# Altium schematic sheet 01_Power.SchDoc
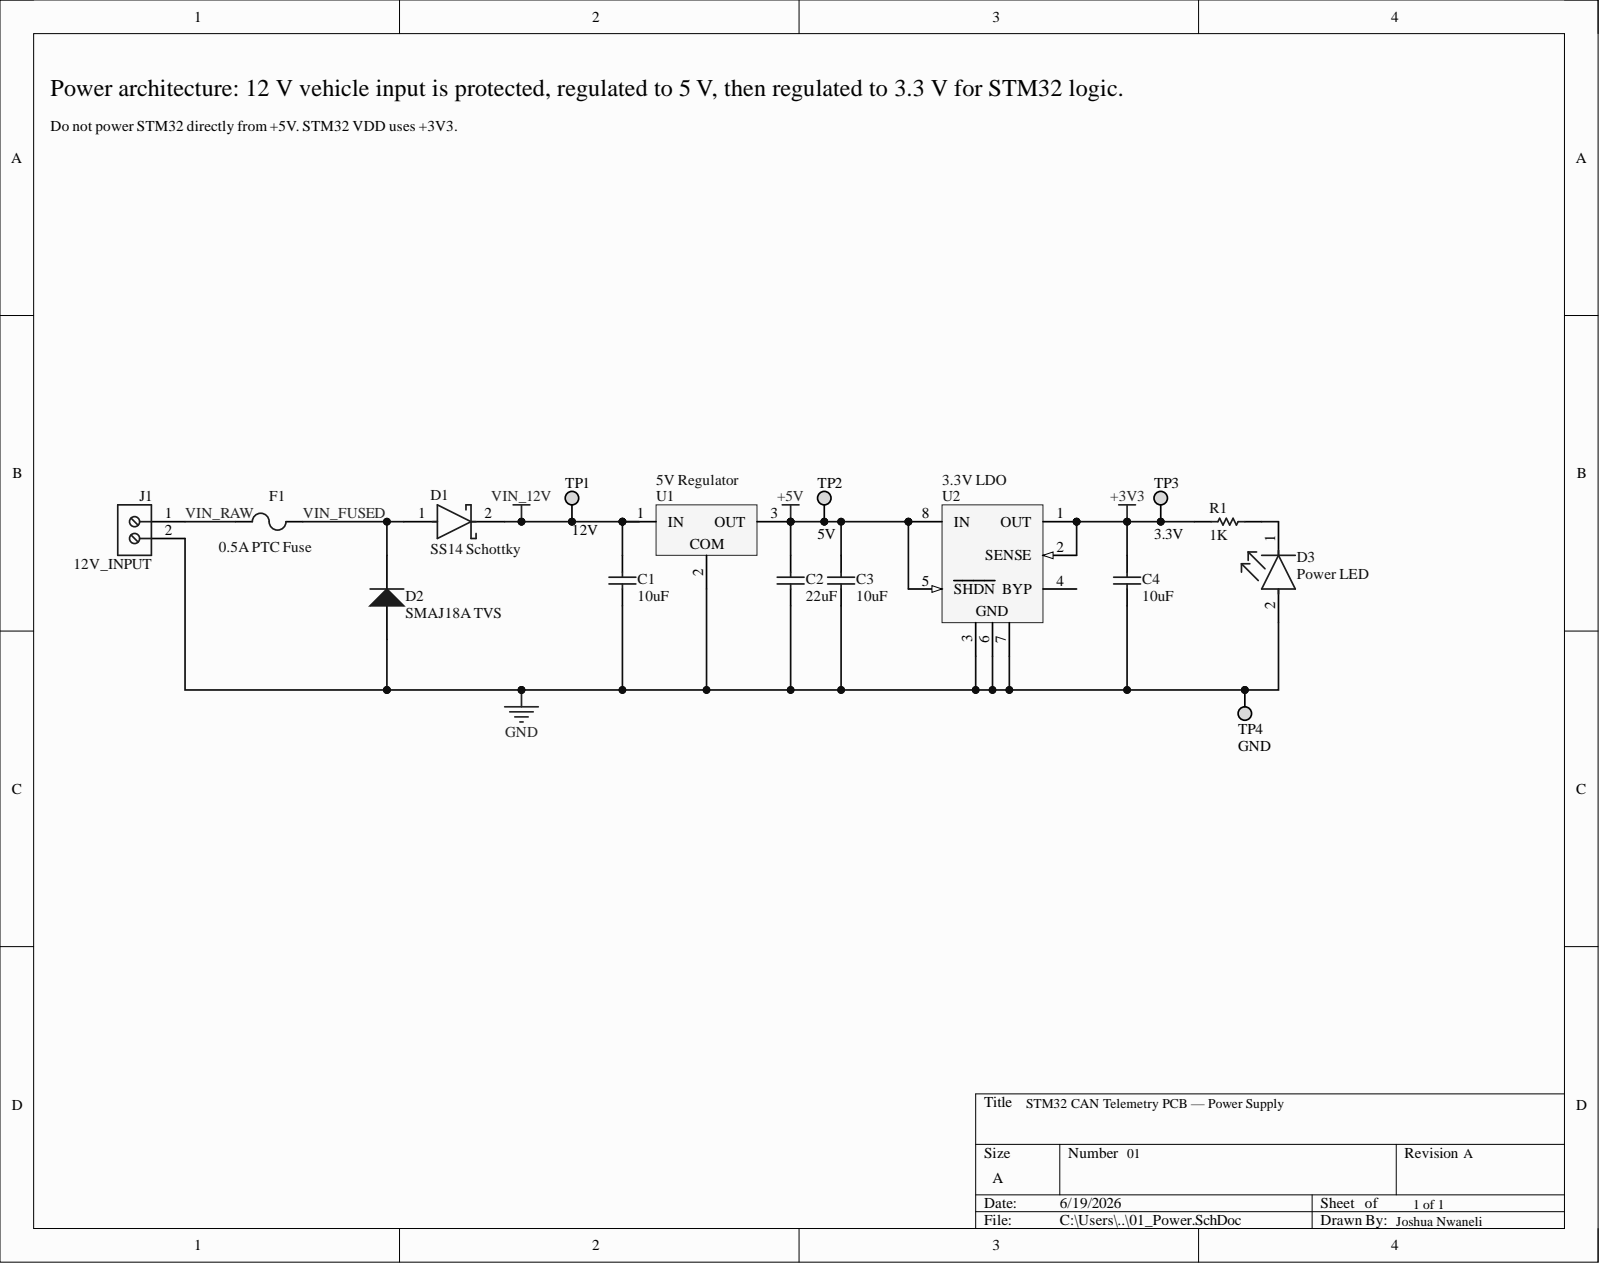
[diagram p0: 01_Power.SchDoc]

## Parts
C1  10uF  [CAPC2013X140X50ML20T25]
C2  22uF  [CAPC1206(3216)190_N]
C3  10uF  [CAPC2013X140X50ML20T25]
C4  10uF  [CAPC2013X140X50ML20T25]
D1  SS14 Schottky  Manufacturer Part Number: SS14  [FP-403AE-MFG]
D2  SMAJ18A TVS  [VISH-DO-214AC-2_M]
D3  Power LED  [FP-SML-LXT0805IW-TR-MFG]
F1  0.5A PTC Fuse  [LFUS-1812L05030PR_V]
J1  12V_INPUT  [691249510002]
R1  1K  [RESC2013X50X30ML20T20]
TP1  12V  [KSTN-5014_V]
TP2  5V  [KSTN-5014_V]
TP3  3.3V  [KSTN-5014_V]
TP4  GND  [KSTN-5014_V]
U1  5V Regulator  [LP3]
U2  3.3V LDO  [LT-S8-8_N]

## Nets
+3V3: C4.2 R1.1 TP3.1 U2.1(OUT) U2.2(SENSE)
+5V: C2.1 C3.2 TP2.1 U1.3 U2.5(SHDN) U2.8(IN)
GND: C1.1 C2.2 C3.1 C4.1 D2.2 D3.2 J1.2 TP4.1 U1.2 U2.3 U2.6 U2.7
VIN_12V: C1.2 D1.2 TP1.1 U1.1
VIN_FUSED: D1.1 D2.1 F1.2
VIN_RAW: F1.1 J1.1
NetD3_1: D3.1 R1.2

Not connected: U2 4(BYP)

## Library data of the parts
C1 C3 C4 = CMP-2007-04702-1: Manufacturer: AVX Interconnect / Elco; Part Number: 0805ZC106KAT2A; Component Kind: Standard; Library Name: Altium Content Vault
C2 = CMP-1037-04990-1: CAP 22uF 10V ±20% 1206 (3216 Metric) Thickness 1.9mm SMD; Case-EIA: 1206; Case-Metric: 3216; Tolerance: Â±20%; Component Kind: Standard; Library Name: Altium Content Vault; Max Thickness: 1.9 mm; Rated Voltage: 10 V; Technology: SMT; Value: 22uF
D1 = CMP-2000-04943-2: DIODE SCHOTTKY 40V 1A SMA; Manufacturer: ON Semiconductor; Max Junction Temp: +125°C; Max Surge Forward Current: 40A; Peak Reverse Current: 0.2mA; Standoff Height: 0.05mm; Automotive: No; Category: Diode; Component Kind: Standard; ComponentLink2Description: Datasheet; ComponentLink2URL: https://www.onsemi.com/pub/Collateral/SS19-D.PDF; Device Class L1: Discrete Semiconductors; Device Class L2: Diodes; Device Class L3: Schottky Diodes; Digikey Description: DIODE SCHOTTKY 40V 1A SMA; Forward Current: 1A; Height: 2.5mm; Lead Free: Yes; Library Name: Altium Content Vault; Max Repetitive Reverse Voltage: 40V; Mouser Description: Schottky Diodes & Rectifiers SMA 40V 1.0A; Octopart: https://octopart.com/ss14-on+semiconductor-29205033; Package: 403AE; RoHS: TRUE; Temperature: -65°C to +125°C; Temperature Range High: +125°C; Temperature Range Low: -65°C
D2 = CMP-0548-00222-2: Transient Voltage Suppressor, 6.40 to 231 V, 18 V Stand-off Voltage, Uni-Directional, -55 to 155 degC, 2-pin DO-214AC, Pb-Free, Tape and Reel; Manufacturer: Vishay Semiconductor; PartNumber: SMAJ18A-E3/61; ID (uA): 1; IFSM: 40 A; PPPM (W): 400, 300; VBR Uni-Directional (V): 6.4 to 231; VWM (V): 18; Component Kind: Standard; ComponentLink1Description: Manufacturer URL; ComponentLink1URL: http://www.vishay.com/; ComponentLink2Description: Datasheet; ComponentLink2URL: http://www.vishay.com/docs/88390/smaj50a.pdf; ComponentLink3Description: Package Specification; ComponentLink3URL: http://www.vishay.com/docs/88390/smaj50a.pdf; DatasheetVersion: 12/8/2013; Library Name: Altium Content Vault; Mounting Technology: Surface Mount; Operating Junction and Storage Temperature Range: -55 to 150 degC; PackageDescription: 2-Pin DO-214AC (SMA), Body 4.245 x 2.665 mm; PackageReference: DO-214AC-2; Packing: Tape and Reel; RoHS: TRUE
D3 = CMP-1724-00002-2: LED RED DIFFUSED SMD; Approvals: UL; Dominant Wavelength: 635nm; Forward Current: 20mA; Forward Voltage: 2V; Lens Color: White; Lens Style: Rectangular; Luminous Intensity: 11mcd; Number of LEDs: 1; Viewing Angle: 140°; Case Code (Imperial): 0805; Case Code (Metric): 2012; Case/Package: 0805; Color: Red; Component Kind: Standard; Height: 0.8mm; Illumination Color: Red; Lead Free: Lead Free; Length: 2mm; Lens Transparency: Diffused; Library Name: Altium Content Vault; Max Operating Temperature: 80°C; Min Operating Temperature: -25°C; Mount: Surface Mount; Number of Pins: 2; Package Quantity: 1; Packaging: Tape and Reel; REACH SVHC: No SVHC; Test Current: 20mA; Wavelength: 635nm; Width: 1.25mm
F1 = CMP-2000-05508-1: PTC Resettable Fuse, 0.8 W, 30 V, -40 to 85 degC, 2-Pin SMD, RoHS, Tape and Reel; Manufacturer: Littelfuse-Inc.; Current - Hold (Ih) (Max): 500mA; Current - Max: 100A; Current - Trip (It): 1A; R Min/Max: 0.150~1.000Ohm; Time to Trip: 0.15s; Voltage - Max: 30V; Category: CircuitProtection; Component Kind: Standard; Datasheet URL: http://www.littelfuse.com/~/media/electronics/datasheets/resettable_ptcs/littelfuse_ptc_1812l_datasheet.pdf.pdf; Datasheet Version: 02/2016; Family: PTCResettableFuses; Library Name: Altium Content Vault; Manufacturer URL: http://www.littelfuse.com/; Mounting Technology: SurfaceMount; Package / Case: 1812(4532Metric),Concave; Package Description: 2-Pin Surface Mount Device, Body 4.55 x 3.24 mm; Package Reference: 1812; Packaging: Tape&Reel(TR); Series: POLY-FUSE®,1812L
J1 = CMP-03913-78871301-2: Terminal Block, 5.08MM Pitch, Double Level Horizontal, 12-30 AWG, 2 Pin; Component Kind: Standard; Lead Pitch: 5.08mm; Library Name: Altium Content Vault; Number of Pins: 2; Packaging: Box
R1 = CMP-2001-04268-1: Manufacturer: Vishay Dale; Part Number: CRCW08051K00FKTC; Component Kind: Standard; Library Name: Altium Content Vault
TP1 = CMP-1672-00006-4: 12V Test Point; Manufacturer: Keystone; Colour: Yellow; Component Kind: Standard; ComponentLink1Description: Manufacturer URL; ComponentLink1URL: http://www.keyelco.com/; ComponentLink2Description: Datasheet; ComponentLink2URL: http://www.keyelco.com/product-pdf.cfm?p=1323; Contact Material: Bronze; Contact Plating: Silver; DatasheetVersion: Rev. F, 12/2013; Height: 8.12 mm; Library Name: Altium Content Vault; Mount: Through Hole; Mounting Technology: Through Hole; Package Quantity: 100; PackageDescription: 1-Pin Through Hole Device, Dia 3.17 mm; PackageReference: 5014; Packaging: Bulk; REACH SVHC: No SVHC; RoHSCompliant: true
TP2 = CMP-1672-00006-4: 5V Test Point; Manufacturer: Keystone; Colour: Yellow; Component Kind: Standard; ComponentLink1Description: Manufacturer URL; ComponentLink1URL: http://www.keyelco.com/; ComponentLink2Description: Datasheet; ComponentLink2URL: http://www.keyelco.com/product-pdf.cfm?p=1323; Contact Material: Bronze; Contact Plating: Silver; DatasheetVersion: Rev. F, 12/2013; Height: 8.12 mm; Library Name: Altium Content Vault; Mount: Through Hole; Mounting Technology: Through Hole; Package Quantity: 100; PackageDescription: 1-Pin Through Hole Device, Dia 3.17 mm; PackageReference: 5014; Packaging: Bulk; REACH SVHC: No SVHC; RoHSCompliant: true
TP3 = CMP-1672-00006-4: 3.3V Test Point; Manufacturer: Keystone; Colour: Yellow; Component Kind: Standard; ComponentLink1Description: Manufacturer URL; ComponentLink1URL: http://www.keyelco.com/; ComponentLink2Description: Datasheet; ComponentLink2URL: http://www.keyelco.com/product-pdf.cfm?p=1323; Contact Material: Bronze; Contact Plating: Silver; DatasheetVersion: Rev. F, 12/2013; Height: 8.12 mm; Library Name: Altium Content Vault; Mount: Through Hole; Mounting Technology: Through Hole; Package Quantity: 100; PackageDescription: 1-Pin Through Hole Device, Dia 3.17 mm; PackageReference: 5014; Packaging: Bulk; REACH SVHC: No SVHC; RoHSCompliant: true
TP4 = CMP-1672-00006-4: Ground Test Point; Manufacturer: Keystone; Colour: Yellow; Component Kind: Standard; ComponentLink1Description: Manufacturer URL; ComponentLink1URL: http://www.keyelco.com/; ComponentLink2Description: Datasheet; ComponentLink2URL: http://www.keyelco.com/product-pdf.cfm?p=1323; Contact Material: Bronze; Contact Plating: Silver; DatasheetVersion: Rev. F, 12/2013; Height: 8.12 mm; Library Name: Altium Content Vault; Mount: Through Hole; Mounting Technology: Through Hole; Package Quantity: 100; PackageDescription: 1-Pin Through Hole Device, Dia 3.17 mm; PackageReference: 5014; Packaging: Bulk; REACH SVHC: No SVHC; RoHSCompliant: true
U1 = CMP-0324-00078-1: Regulates protected 12V input down to 5V; Manufacturer: Texas Instruments; PartNumber: UA78M05IKCSE3; Eco-Plan: Pb-Free (RoHS); Iout(Max)(A): 0.5; Iq(Typ)(mA): 6; Vin(Max)(V): 25; Vin(Min)(V): 7; Vout(Max)(V): 5; Code_JEDEC: TO-226-AA; Component Kind: Standard; ComponentLink1Description: Datasheet; ComponentLink1URL: http://www.ti.com/general/docs/lit/getliterature.tsp?genericPartNumber=UA78M05&fileType=pdf; ComponentLink2Description: URL Link1; ComponentLink2URL: Samples URL:http://www.ti.com/product/ua78m05#samples; DatasheetVersion: SLVS059Q; Library Name: Altium Content Vault; Mounting Technology: Through Hole; PackageDescription: Plastic Cylindrical Package, Formed Lead Option (TO-92); PackageReference: LP3; PackageVersion: revC, Oct-2001; Vout(Min)(V): 5
U2 = CMP-0476-01566-1: 500 mA, Low Noise, LDO Micropower Regulator, 1.8 to 20 V Vin, 3.3 V Vout, 8-pin SOIC (S8-8), -40 to 125 degC, Pb-Free; Manufacturer: Linear Technology; PartNumber: LT1763IS8-3.3#PBF; Discrete Pass Element: no; Dropout Voltage(V): 0.3; Iout(mA): 500; Isupply(mA): 0.03; Noise(uV): 20; Number of Outputs: 1; PSRR(dB): 65; Vin Min(V): 1.8; Component Kind: Standard; ComponentLink1Description: Manufacturer URL; ComponentLink1URL: http://www.linear.com/; ComponentLink2Description: Datasheet; ComponentLink2URL: http://cds.linear.com/docs/en/datasheet/1763fg.pdf; ComponentLink3Description: Package Specification; ComponentLink3URL: http://cds.linear.com/docs/en/packaging/05081610_G_SO8.pdf; DatasheetVersion: Rev. G; Library Name: Altium Content Vault; Mounting Technology: Surface Mount; Output Voltage: 3.3 V; PackageDescription: 8-Pin Plastic Small Outline (Narrow .150 Inch), Body 4.9 x 3.9 mm, Pitch 1.27 mm; PackageReference: S8-8; PackageVersion: Rev. G, 03/2012; RoHS: TRUE; Shutdown Current(uA): 1; Vin Max(V): 20
